# Altium schematic sheet BM.SchDoc(BM)
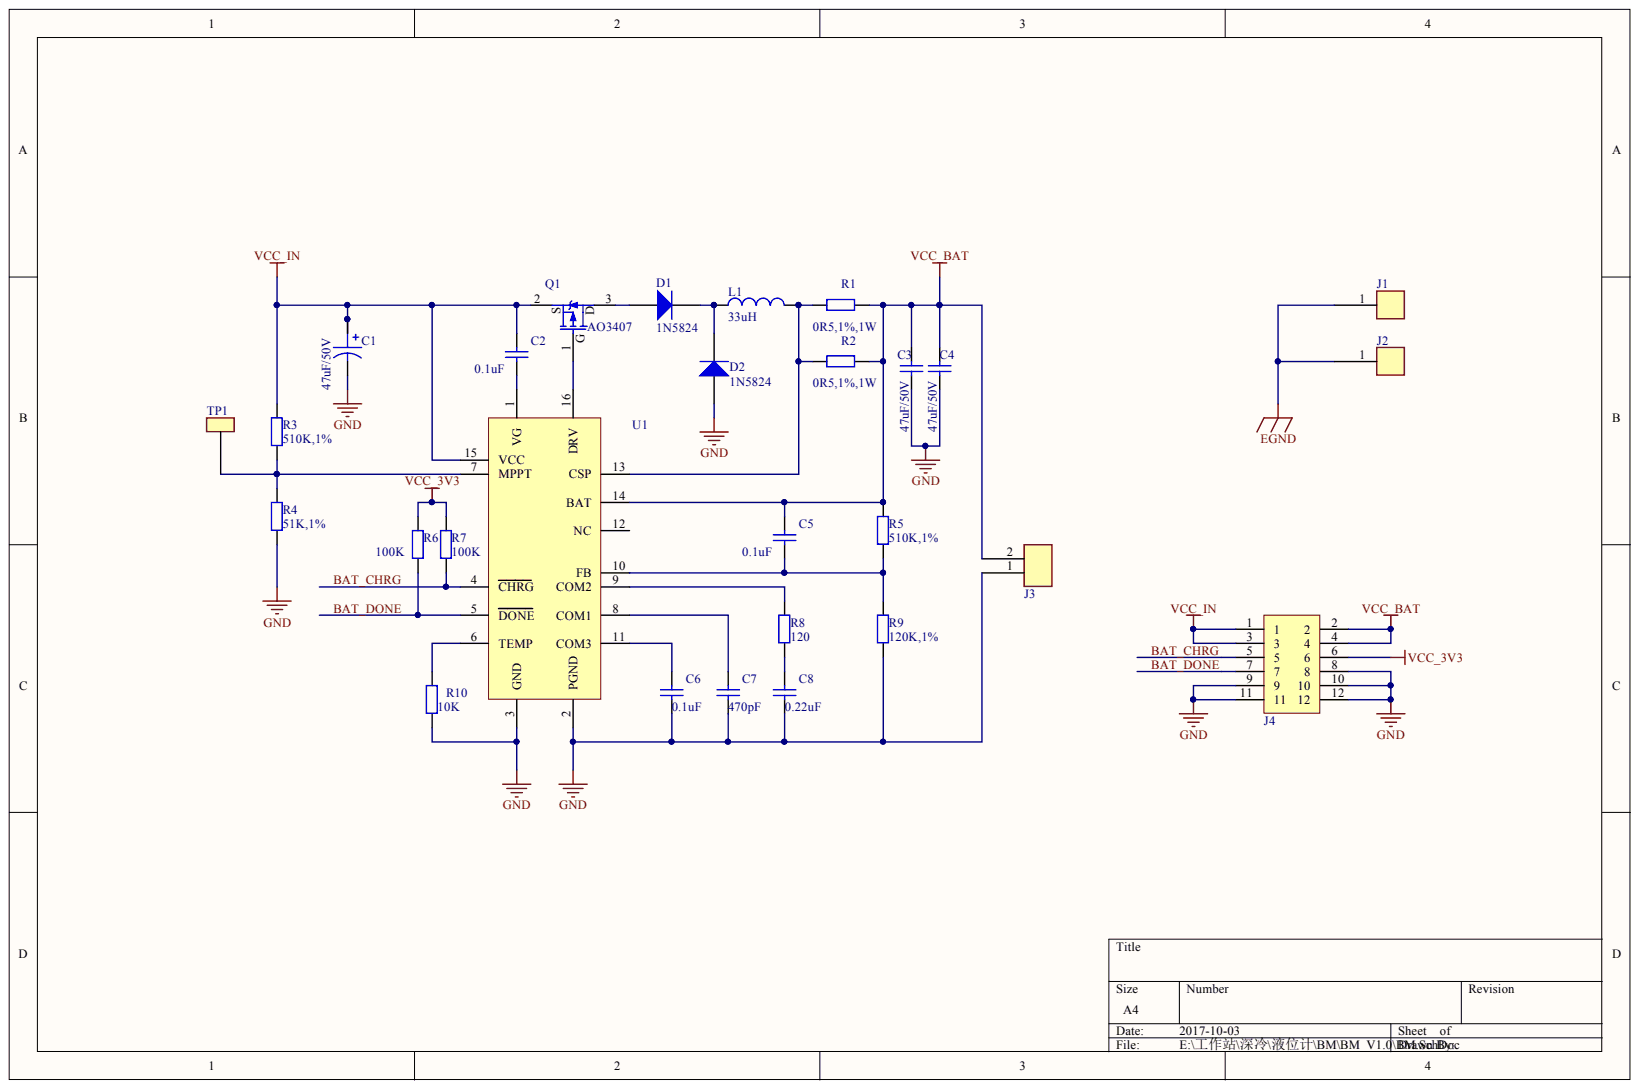
[diagram p0: BM.SchDoc]

## Parts
C1  47uF/50V  [CAP6X7]
C2  value 0.1uF  [0603C]  (hidden comment: Capacitor)
C3  value 47uF/50V  [1206]  (hidden comment: Capacitor)
C4  value 47uF/50V  [1206]  (hidden comment: Capacitor)
C5  value 0.1uF  [0603C]  (hidden comment: Capacitor)
C6  value 0.1uF  [0603C]  (hidden comment: Capacitor)
C7  value 470pF  [0603C]  (hidden comment: Capacitor)
C8  value 0.22uF  [0603C]  (hidden comment: Capacitor)
D1  value 1N5824  [DO214AC(SMA)]  (hidden comment: Diode)
D2  value 1N5824  [DO214AC(SMA)]  (hidden comment: Diode)
J1  CON1  [MHT_4mm]  (value not drawn)
J2  CON1  [MHT_4mm]  (value not drawn)
J3  CON2  [XH2.54-2P]  (value not drawn)
J4  Header 6x2  [SOP2.0-2x6]  (value not drawn)
L1  33uH  [0630]
Q1  value AO3407  [SOT23]  (hidden comment: P-CH MOS)
R1  value 0R5,1%,1W  [2512]  (hidden comment: Resistor)
R2  value 0R5,1%,1W  [2512]  (hidden comment: Resistor)
R3  value 510K,1%  [L0603]  (hidden comment: Resistor)
R4  value 51K,1%  [L0603]  (hidden comment: Resistor)
R5  value 510K,1%  [L0603]  (hidden comment: Resistor)
R6  value 100K  [L0603]  (hidden comment: Resistor)
R7  value 100K  [L0603]  (hidden comment: Resistor)
R8  value 120  [L0603]  (hidden comment: Resistor)
R9  value 120K,1%  [L0603]  (hidden comment: Resistor)
R10  value 10K  [0603R]  (hidden comment: Resistor)
TP1  TEST POINT_0  [TP]  (value not drawn)
U1  CN3722  [TSSOP16]  (value not drawn)

## Nets
EGND: J1.1 J2.1
GND: C1.2 C3.2 C4.2 C6.2 C7.2 C8.2 D2.1 J3.1 J4.8 J4.9 J4.10 J4.11 J4.12 R4.2 R9.2 R10.1 U1.2(PGND) U1.3(GND)
VCC_3V3: J4.6 R6.2 R7.2
VCC_BAT: C3.1 C4.1 C5.1 J3.2 J4.2 J4.4 R1.1 R2.2 R5.1 U1.14(BAT)
VCC_IN: C1.1 C2.1 J4.1 J4.3 Q1.2 R3.1 U1.15(VCC)
BAT_CHRG: J4.5 R7.1 U1.4(CHRG)
BAT_DONE: J4.7 R6.1 U1.5(DONE)
NetC2_2: C2.2 U1.1(VG)
NetC5_2: C5.2 R5.2 R9.1 U1.10(FB)
NetC6_1: C6.1 U1.11(COM3)
NetC7_1: C7.1 U1.8(COM1)
NetC8_1: C8.1 R8.2
NetD1_1: D1.1 Q1.3
NetD1_2: D1.2 D2.2 L1.1
NetL1_2: L1.2 R1.2 R2.1 U1.13(CSP)
NetQ1_1: Q1.1 U1.16(DRV)
NetR3_2: R3.2 R4.1 TP1.1 U1.7(MPPT)
NetR8_1: R8.1 U1.9(COM2)
NetR10_2: R10.2 U1.6(TEMP)

Not connected: U1 12(NC)

## Library data of the parts
C1 = CAPACITOR POL_3: Value: 330uF/50V; Component Kind: Standard; Library Name: SENSOR_BOARD_Library.SchLib
C2-C8 = Cap: Component Kind: Standard; Description: Capacitor; LatestRevisionDate: 17-Jul-2002; LatestRevisionNote: Re-released for DXP Platform.; Library Name: Miscellaneous Devices.IntLib; PackageDescription: Radial Cap, Thru-Hole; 2 Leads; 0.3 in Pin Spacing; PackageReference: RAD-0.3; Published: 8-Jun-2000; Signal Integrity: Cap; Simulation: CAP; Publisher: Altium Limited
J3 = CON2: Value: XH2.54-2P; Component Kind: Standard; Library Name: MAINBOARD_Library.SchLib
J4 = Header 6x2: Value: 2.0间距贴片双排针; Component Kind: Standard; Library Name: MyLib.SCHLIB
L1 = Inductor: Value: 33uH,AMPI104CEL330MT; Component Kind: Standard; Library Name: LNG.SCHLIB
R1 = Res: Value: 0R5,1%,1W; Component Kind: Standard; Library Name: Lib1.SCHLIB
R2-R10 = Res: Component Kind: Standard; Library Name: Lib1.SCHLIB
D1 D2 = Diode: Component Kind: Standard; Library Name: zhileng.SchLib
J1 J2 = CON1: Component Kind: Standard; Library Name: MAINBOARD_Library.SchLib
Q1 = P-CH MOS: Component Kind: Standard; Library Name: LNG.SCHLIB
TP1 = TEST POINT_0: Component Kind: Standard; Library Name: MAINBOARD_Library.SchLib
U1 = CN3722: Component Kind: Standard; Library Name: MyLib.SCHLIB; Value: CN3722
